# Altium schematic sheet QuadCurrentLoopCape.SchDoc(QuadCurrentLoopCape)
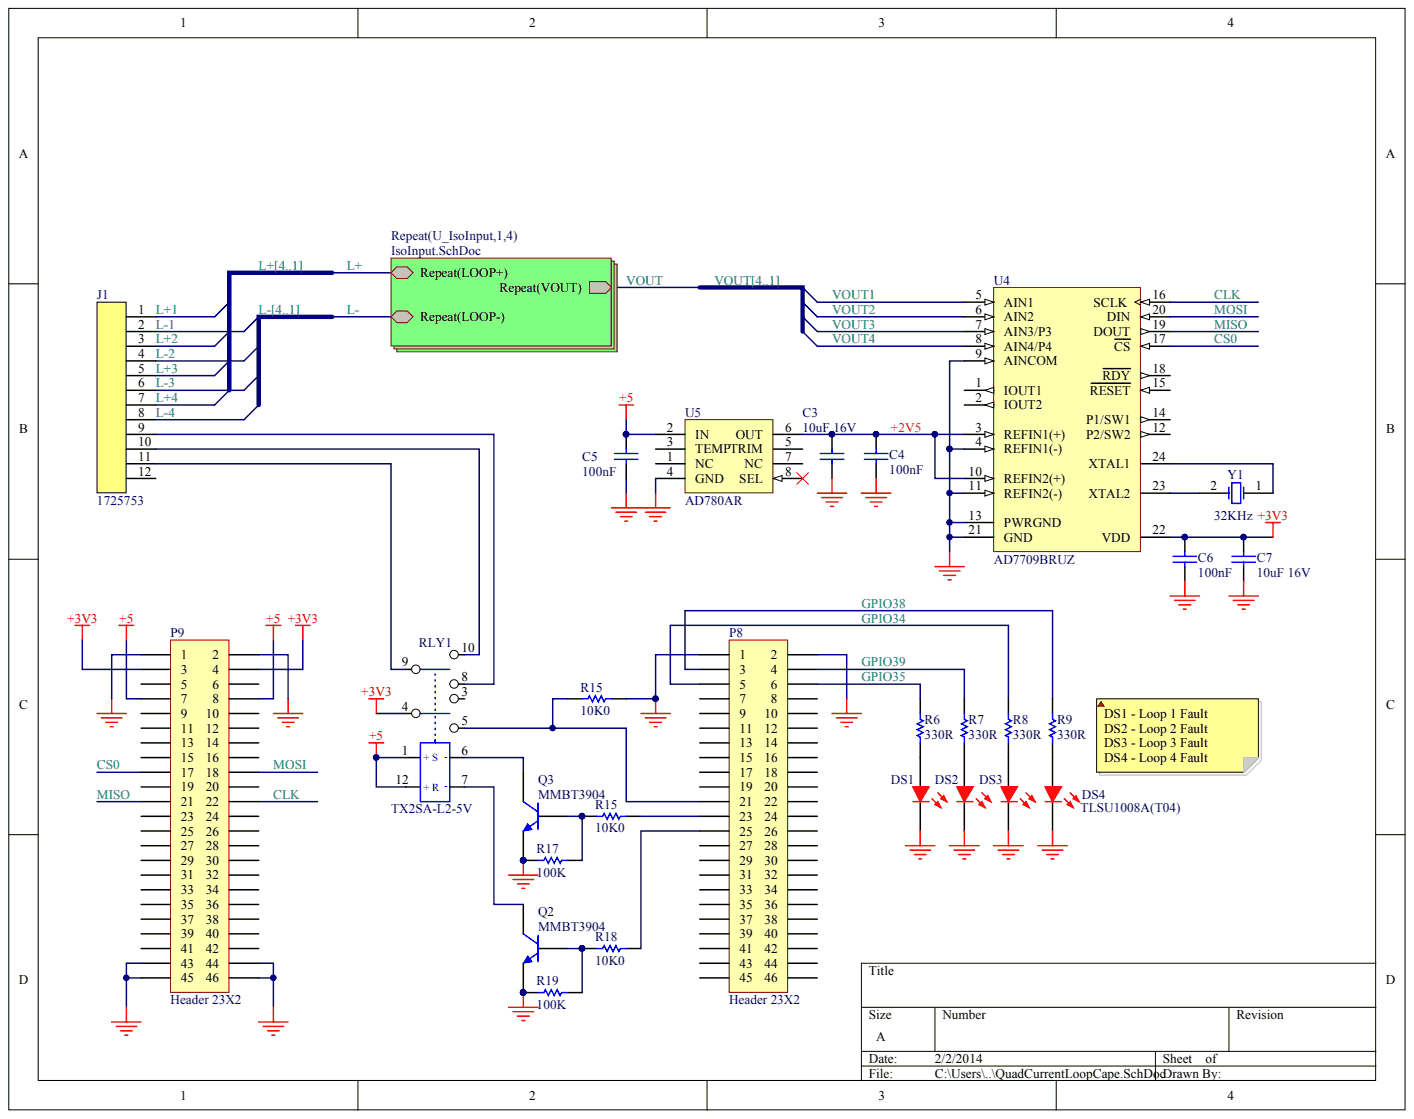
[diagram p0: QuadCurrentLoopCape.SchDoc]

## Parts
C3  value 10uF 16V  (hidden comment: CAP 10uF 16V 0805(2012))
C4  value 100nF  (hidden comment: CAP 100nF 50V 0805(2012))
C5  value 100nF  (hidden comment: CAP 100nF 50V 0805(2012))
C6  value 100nF  (hidden comment: CAP 100nF 50V 0805(2012))
C7  value 10uF 16V  (hidden comment: CAP 10uF 16V 0805(2012))
DS1  TLSU1008A(T04)
DS2  TLSU1008A(T04)
DS3  TLSU1008A(T04)
DS4  TLSU1008A(T04)
J1  1725753
P8  Header 23X2
P9  Header 23X2
Q2  MMBT3904
Q3  MMBT3904
R6  value 330R  (hidden comment: 330R 1% 0805(2012))
R7  value 330R  (hidden comment: 330R 1% 0805(2012))
R8  value 330R  (hidden comment: 330R 1% 0805(2012))
R9  value 330R  (hidden comment: 330R 1% 0805(2012))
R15  10K0
R15  10K0
R17  100K
R18  10K0
R19  100K
RLY1  TX2SA-L2-5V
U4  AD7709BRUZ
U5  AD780AR
Y1  32KHz

## Nets
+3V3: C6.2 C7.2 P9.3 P9.4 RLY1.4 U4.22(VDD)
+5: C5.2 P9.7 P9.8 RLY1.1 RLY1.12 U5.2(IN)
GND: C3.1 C4.1 C5.1 C6.1 C7.1 DS1.2 DS2.2 DS3.2 DS4.2 P8.1 P8.2 P9.1 P9.2 P9.43 P9.44 P9.45 P9.46 Q2.2 Q3.2 R15.1 R17.2 R19.2 U4.4(REFIN1(-)) U4.9(AINCOM) U4.11(REFIN2(-)) U4.13(PWRGND) U4.21(GND) U5.4(GND)
CLK: P9.22 U4.16(SCLK)
CS0: P9.17 U4.17(CS)
GPIO34: P8.5 R8.2
GPIO35: P8.6 R6.2
GPIO38: P8.3 R9.2
GPIO39: P8.4 R7.2
L+1: J1.1  [also labelled L+]
L+2: J1.3  [also labelled L+]
L+3: J1.5  [also labelled L+]
L+4: J1.7  [also labelled L+]
L-1: J1.2  [also labelled L-]
L-2: J1.4  [also labelled L-]
L-3: J1.6  [also labelled L-]
L-4: J1.8  [also labelled L-]
MISO: P9.21 U4.19(DOUT)
MOSI: P9.18 U4.20(DIN)
VOUT1: U4.5(AIN1)  [also labelled VOUT]
VOUT2: U4.6(AIN2)  [also labelled VOUT]
VOUT3: U4.7(AIN3/P3)  [also labelled VOUT]
VOUT4: U4.8(AIN4/P4)  [also labelled VOUT]
NetC3_2: C3.2 C4.2 U4.3(REFIN1(+)) U4.10(REFIN2(+)) U5.6(OUT)
NetDS1_1: DS1.1 R6.1
NetDS2_1: DS2.1 R7.1
NetDS3_1: DS3.1 R8.1
NetDS4_1: DS4.1 R9.1
NetJ1_9: J1.9 RLY1.8
NetJ1_10: J1.10 RLY1.10
NetJ1_11: J1.11 RLY1.9
NetJ1_12: J1.12
NetP8_7: P8.7
NetP8_8: P8.8
NetP8_9: P8.9
NetP8_10: P8.10
NetP8_11: P8.11
NetP8_12: P8.12
NetP8_13: P8.13
NetP8_14: P8.14
NetP8_15: P8.15
NetP8_16: P8.16
NetP8_17: P8.17
NetP8_18: P8.18
NetP8_19: P8.19
NetP8_20: P8.20
NetP8_21: P8.21 R15.2 RLY1.5
NetP8_22: P8.22
NetP8_23: P8.23 R15.2
NetP8_24: P8.24
NetP8_25: P8.25 R18.2
NetP8_26: P8.26
NetP8_27: P8.27
NetP8_28: P8.28
NetP8_29: P8.29
NetP8_30: P8.30
NetP8_31: P8.31
NetP8_32: P8.32
NetP8_33: P8.33
NetP8_34: P8.34
NetP8_35: P8.35
NetP8_36: P8.36
NetP8_37: P8.37
NetP8_38: P8.38
NetP8_39: P8.39
NetP8_40: P8.40
NetP8_41: P8.41
NetP8_42: P8.42
NetP8_43: P8.43
NetP8_44: P8.44
NetP8_45: P8.45
NetP8_46: P8.46
NetP9_5: P9.5
NetP9_6: P9.6
NetP9_9: P9.9
NetP9_10: P9.10
NetP9_11: P9.11
NetP9_12: P9.12
NetP9_13: P9.13
NetP9_14: P9.14
NetP9_15: P9.15
NetP9_16: P9.16
NetP9_19: P9.19
NetP9_20: P9.20
NetP9_23: P9.23
NetP9_24: P9.24
NetP9_25: P9.25
NetP9_26: P9.26
NetP9_27: P9.27
NetP9_28: P9.28
NetP9_29: P9.29
NetP9_30: P9.30
NetP9_31: P9.31
NetP9_32: P9.32
NetP9_33: P9.33
NetP9_34: P9.34
NetP9_35: P9.35
NetP9_36: P9.36
NetP9_37: P9.37
NetP9_38: P9.38
NetP9_39: P9.39
NetP9_40: P9.40
NetP9_41: P9.41
NetP9_42: P9.42
NetQ2_1: Q2.1 R18.1 R19.1
NetQ2_3: Q2.3 RLY1.7(-)
NetQ3_1: Q3.1 R15.1 R17.1
NetQ3_3: Q3.3 RLY1.6(-)
NetRLY1_3: RLY1.3
NetU4_1: U4.1(IOUT1)
NetU4_2: U4.2(IOUT2)
NetU4_12: U4.12(P2/SW2)
NetU4_14: U4.14(P1/SW1)
NetU4_15: U4.15(RESET)
NetU4_18: U4.18(RDY)
NetU4_23: U4.23(XTAL2) Y1.2
NetU4_24: U4.24(XTAL1) Y1.1
NetU5_1: U5.1(NC)
NetU5_3: U5.3(TEMP)
NetU5_5: U5.5(TRIM)
NetU5_7: U5.7(NC)
NetU5_8: U5.8(SEL)

## Library data of the parts
C3 C7 = (no symbol name): Tolerance: ±20%; Case-EIA: 0805; Case-Metric: 2012; Rated Voltage: 16 V; Technology: SMT; Max Thickness: 1.45 mm
C4-C6 = (no symbol name): Tolerance: ±20%; Case-Metric: 2012; Case-EIA: 0805; Max Thickness: 1.45 mm; Technology: SMT; Rated Voltage: 50 V
DS1-DS4 = (no symbol name): Manufacturer: Toshiba; Forward Current: 25 mA; Power Dissipation: 60 mW; Packing Type: Reel; Reverse Voltage: 4 V; Mounting Technology: Surface Mount; PackageDescription: 2-Pin SMT LED, 1.6 x 0.8 mm Pitch; ComponentLink1URL: http://www.toshiba-components.com/; ComponentLink1Description: Manufacturer URL; PackageReference: 0603LED_RED; PartNumber: TLSU1008A(T04); ComponentLink2URL: http://www.semicon.toshiba.co.jp/info/docget.jsp?type=datasheet&lang=en&pid=TLSU1008A(T04); ComponentLink2Description: Datasheet; DatasheetVersion: Aug-08; Storage Temperature: -40 to 100 degC
Q2 Q3 = (no symbol name): AML Part Number: TRN-S0002; Published: 17-Sep-2003; LatestRevisionDate: 12-Dec-2006; LatestRevisionNote: IPC-7351 Footprint Added.; DatasheetVersion: 13-May-2002; PackageVersion: May-1999; PackageReference: SOT23; PackageDescription: 3-Pin SOT-23 Package 0.95 mm Pitch, 2.3 mm Lead Span; ComponentLink1Description: Manufacturer Link; ComponentLink1URL: http://www.semiconductors.philips.com/; ComponentLink2Description: Datasheet; ComponentLink2URL: http://www.semiconductors.philips.com/acrobat/datasheets/MMBT3904_4.pdf; Publisher: Altium Limited
R6-R9 = (no symbol name): Case-EIA: 0805; Power: 0.125 W; Case-Metric: 2012; Tolerance: 1 %; Technology: SMT
R15 R15 R18 = (no symbol name): Power: 0.1 W; Value: 10000.00 Ohm; Tolerance: 1 %; Case-EIA: 0603; Case-Metric: 1608; Technology: SMT
R17 = (no symbol name): AML Part Number: RES-S0242; Value: 100000.00 Ohm; Case-Metric: 1608; Power: 0.1 W; Case-EIA: 0603; Tolerance: 1 %; Technology: SMT
R19 = (no symbol name): AML PArt Number: RES-S0242; Value: 100000.00 Ohm; Case-Metric: 1608; Power: 0.1 W; Case-EIA: 0603; Tolerance: 1 %; Technology: SMT
U4 = (no symbol name): Manufacturer: Analog Devices; Generic Part Number: AD7709; Interface: Ser, SPI; Packing: Tube; Resolution (Bits): 16; Throughput Rate (SPS): 105; Channels: 4; ComponentLink4Description: Product URL; DatasheetVersion: Rev.A; ComponentLink3Description: Package Specification; PackageReference: RU-24; PackageDescription: 24-Lead Thin Shrink Small Outline Package [TSSOP]; RoHS: Y; Code_JEDEC: MO-153-AD; ComponentLink1Description: Manufacturer URL; PackageVersion: 2002; ComponentLink2URL: http://www.analog.com/static/imported-files/data_sheets/AD7709.pdf; ComponentLink4URL: http://www.analog.com/en/analog-to-digital-converters/ad-converters/ad7709/products/product.html; PartNumber: AD7709BRUZ; ComponentLink2Description: Datasheet; ComponentLink3URL: http://www.analog.com/static/imported-files/packages/380523655245964505593671154107510036154RU24.pdf; Mounting Technology: Surface Mount; ComponentLink1URL: http://www.analog.com/en/index.html; Supplier 1: Digi-Key; Supplier Part Number 1: AD7709BRUZ-ND
U5 = (no symbol name): Note: Pins 1 and 7 are not connected; Published: 8-Jun-2000; LatestRevisionDate: 13-Apr-2006; LatestRevisionNote: IPC-7351 Footprint Added.; DatasheetVersion: REV. A; PackageVersion: revC; PackageReference: R-8C; PackageDescription: 8-Pin Small Outline Integrated Circuit 1.27 mm Pitch; Code_IPC: SOIC127P600-8; ComponentLink1Description: Manufacturer Link; ComponentLink1URL: http://www.analog.com/en/prod/0,,769_838_AD780%2C00.html; ComponentLink2Description: Datasheet; ComponentLink2URL: http://www.analog.com/UploadedFiles/Data_Sheets/87683462AD780_e.pdf; Supplier 1: Digi-Key; Supplier Part Number 1: AD780ARZ-ND; Publisher: Altium Limited
J1 P8 P9 RLY1 Y1 = (no symbol name)
  J1: Supplier 1: Digi-Key; Supplier Part Number 1: 277-1283-ND
  RLY1: Supplier 1: Digi-Key; Supplier Part Number 1: 255-1790-5-ND
  Y1: Published: 8-Jun-2000; LatestRevisionDate: 17-Jul-2002; LatestRevisionNote: Re-released for DXP Platform.; PackageReference: R38; PackageDescription: Crystal, Thru-Hole; 2 Leads; Body 3.1 x 8.2 mm (Dia.xH); Publisher: Altium Limited
